# Altium schematic sheet BG0249_R1M1E1_BW235_IMX378.SchDoc(BG0249_R1M1E1_BW235_IMX378)
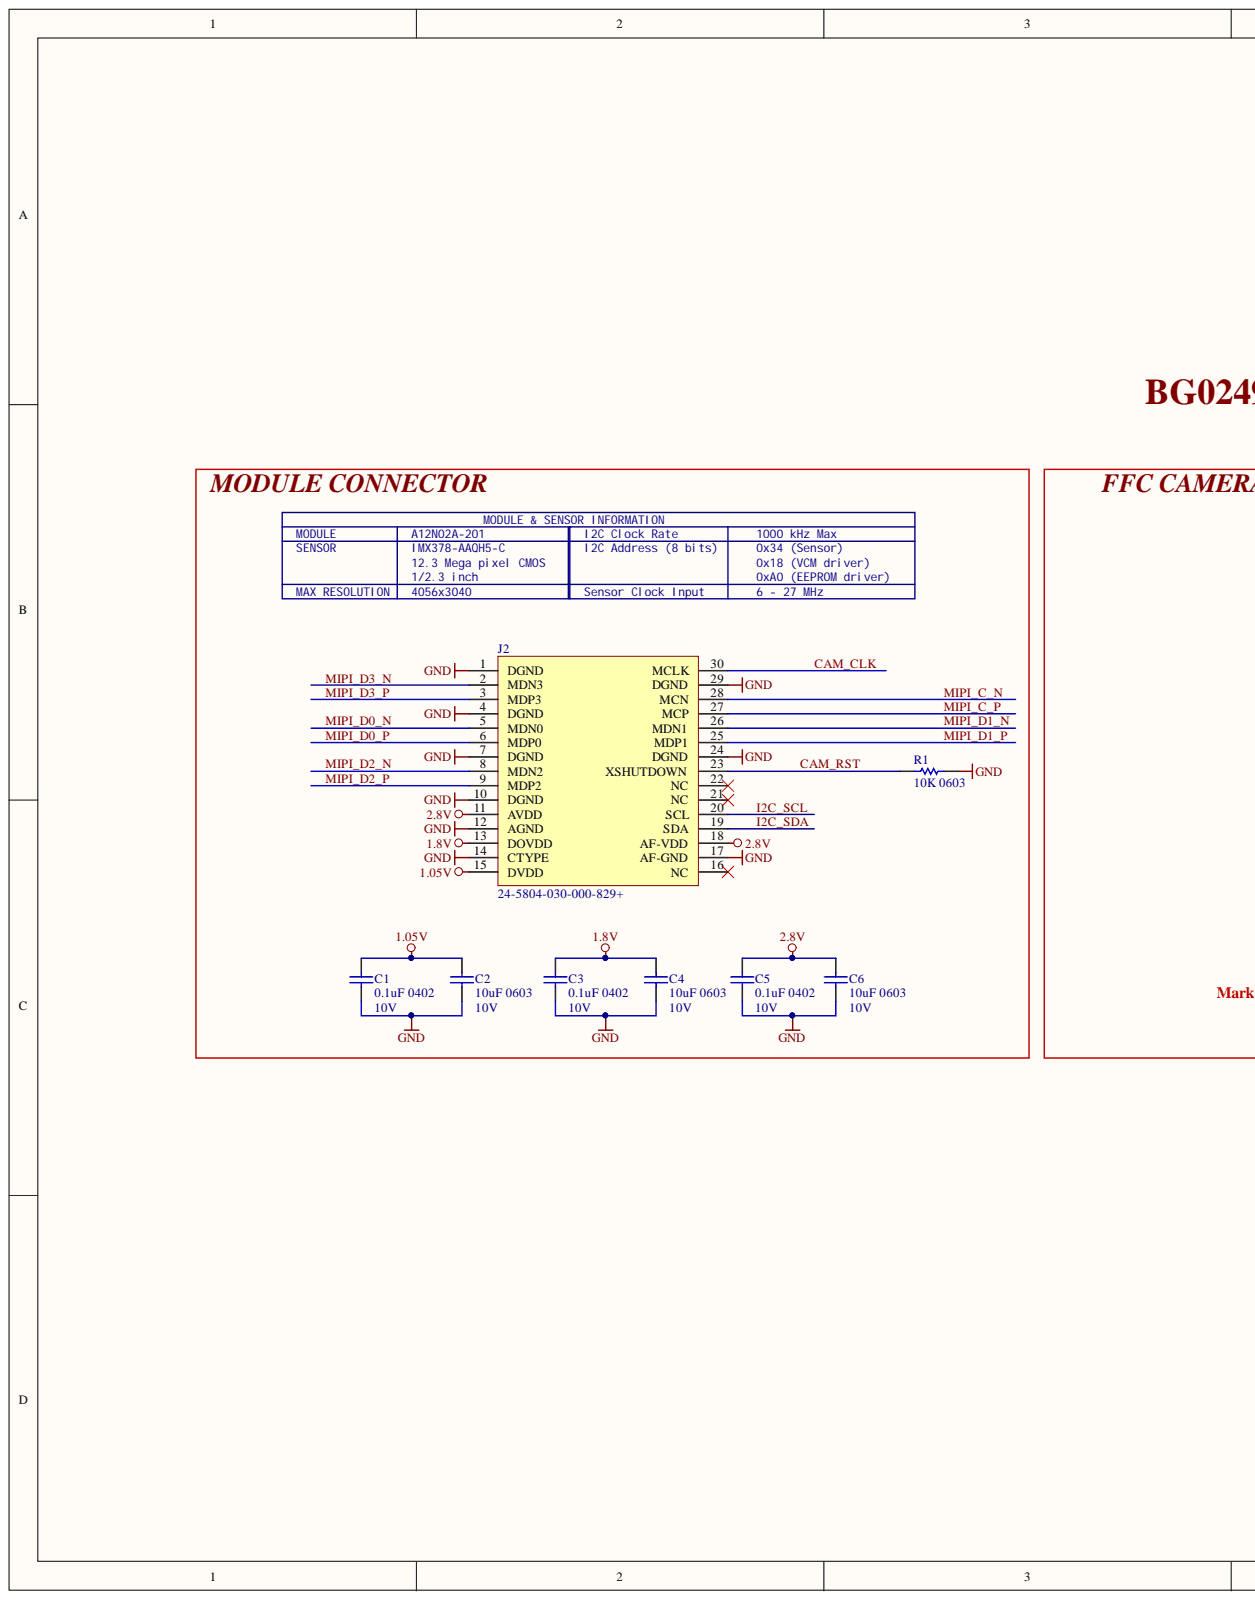
[diagram p0: BG0249_R1M1E1_BW235_IMX378.SchDoc, left]
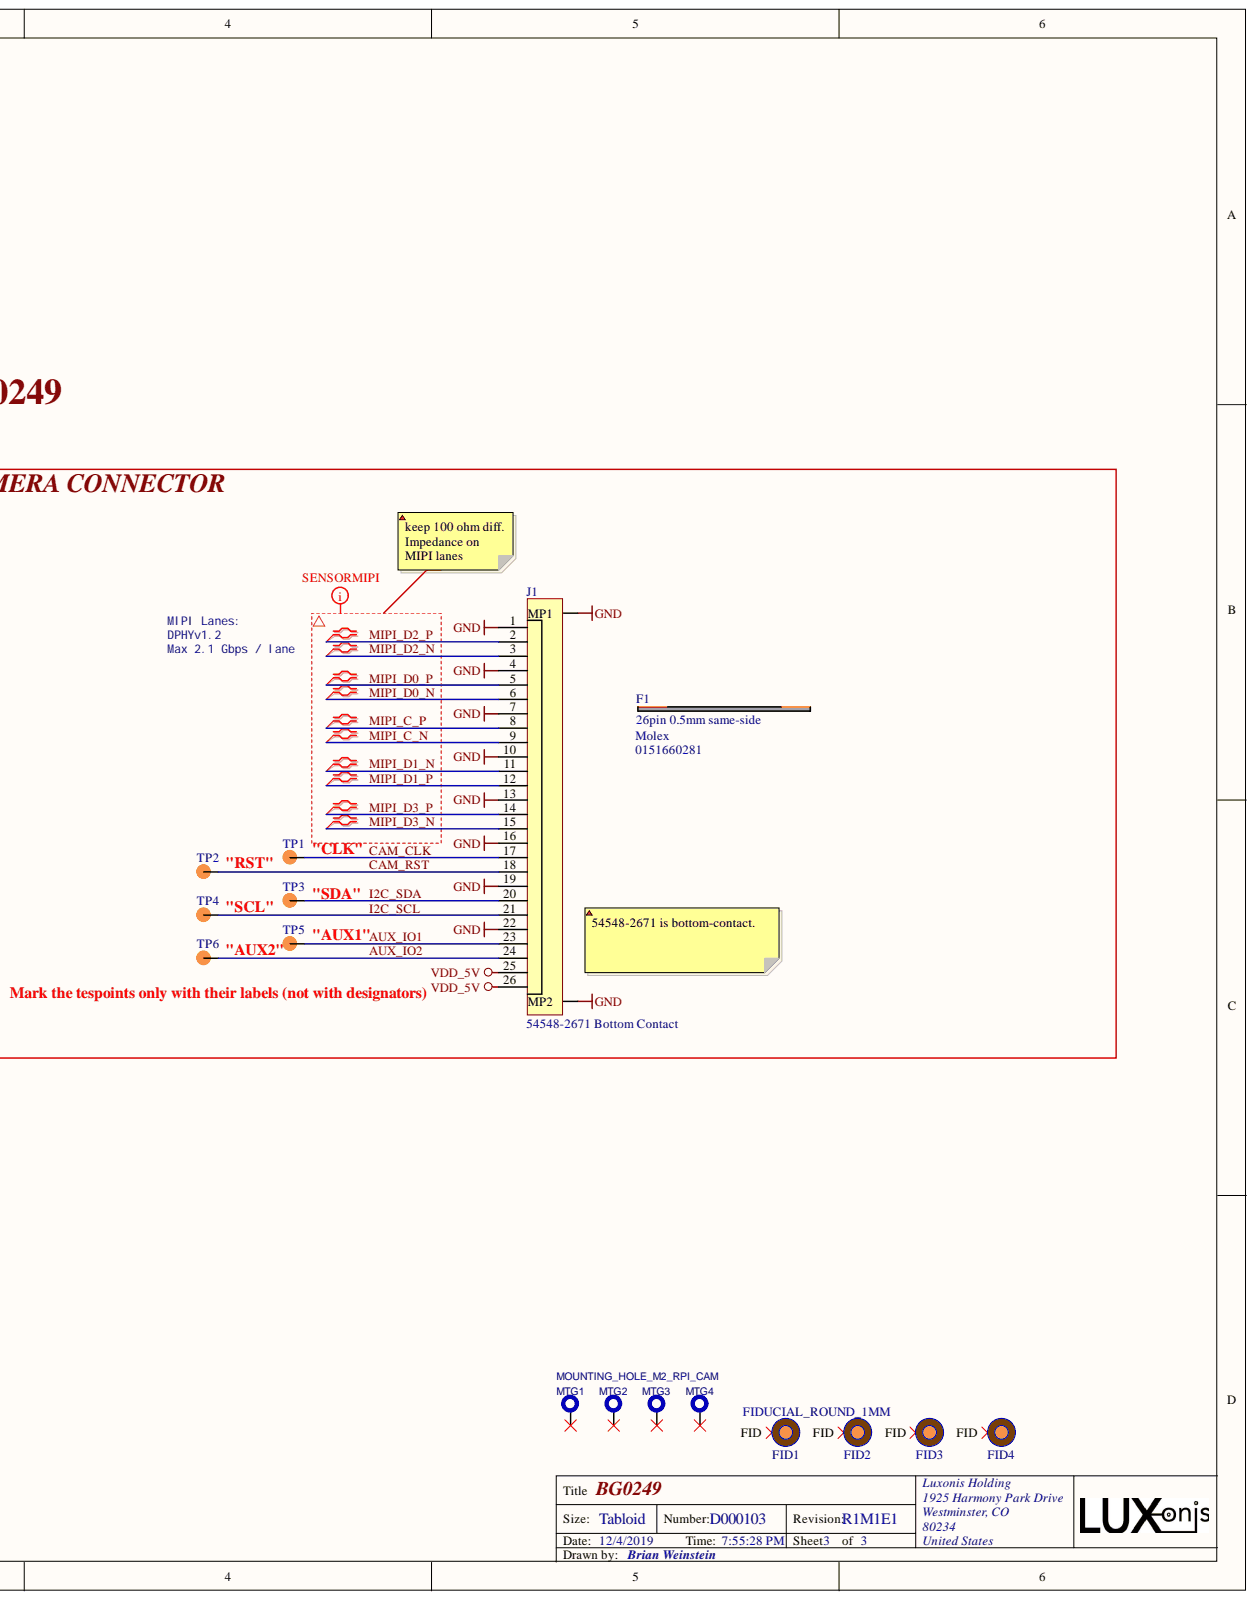
[diagram p1: BG0249_R1M1E1_BW235_IMX378.SchDoc, right]

## Parts
C1  0.1uF 0402  Voltage Rating (DC): 10V  [CAPC0402(1005)70_N]
C2  10uF 0603  Voltage Rating (DC): 10V  Capacitance: 10uF  [CAPC0603(1608)100_N]
C3  0.1uF 0402  Voltage Rating (DC): 10V  [CAPC0402(1005)70_N]
C4  10uF 0603  Voltage Rating (DC): 10V  Capacitance: 10uF  [CAPC0603(1608)100_N]
C5  0.1uF 0402  Voltage Rating (DC): 10V  [CAPC0402(1005)70_N]
C6  10uF 0603  Voltage Rating (DC): 10V  Case Code (Imperial): 0603  Capacitance: 10uF  [CAPC0603(1608)100_N]
F1  26pin 0.5mm same-side  Manufacturer 1: Molex  Cable Pitch: 0.5mm  (mechanical)
FID1  FIDUCIAL_ROUND_1MM  [FIDUCIAL_ROUND_1MM]  (no BOM)
FID2  FIDUCIAL_ROUND_1MM  [FIDUCIAL_ROUND_1MM]  (no BOM)
FID3  FIDUCIAL_ROUND_1MM  [FIDUCIAL_ROUND_1MM]  (no BOM)
FID4  FIDUCIAL_ROUND_1MM  [FIDUCIAL_ROUND_1MM]  (no BOM)
J1  54548-2671 Bottom Contact  [54548-2671]
J2  24-5804-030-000-829+  [A12N02A-201_MatedModule]
MTG1  MOUNTING_HOLE_M2_RPI_CAM  [MOUNTING_HOLE_M2_RPI_CAM]  (no BOM)
MTG2  MOUNTING_HOLE_M2_RPI_CAM  [MOUNTING_HOLE_M2_RPI_CAM]  (no BOM)
MTG3  MOUNTING_HOLE_M2_RPI_CAM  [MOUNTING_HOLE_M2_RPI_CAM]  (no BOM)
MTG4  MOUNTING_HOLE_M2_RPI_CAM  [MOUNTING_HOLE_M2_RPI_CAM]  (no BOM)
R1  10K 0603  Case Code (Imperial): 0603  [RESC0603(1608)_N]
TP1  TP100_150_NS  [TP100_150_NS]  (value not drawn)  (no BOM)
TP2  TP100_150_NS  [TP100_150_NS]  (value not drawn)  (no BOM)
TP3  TP100_150_NS  [TP100_150_NS]  (value not drawn)  (no BOM)
TP4  TP100_150_NS  [TP100_150_NS]  (value not drawn)  (no BOM)
TP5  TP100_150_NS  [TP100_150_NS]  (value not drawn)  (no BOM)
TP6  TP100_150_NS  [TP100_150_NS]  (value not drawn)  (no BOM)

## Nets
1.05V: C1.2 C2.2 J2.15(DVDD)
1.8V: C3.2 C4.2 J2.13(DOVDD)
2.8V: C5.2 C6.2 J2.11(AVDD) J2.18(AF-VDD)
GND: C1.1 C2.1 C3.1 C4.1 C5.1 C6.1 J1.1 J1.4 J1.7 J1.10 J1.13 J1.16 J1.19 J1.22 J1.MP1 J1.MP2 J2.1(DGND) J2.4(DGND) J2.7(DGND) J2.10(DGND) J2.12(AGND) J2.14(CTYPE) J2.17(AF-GND) J2.24(DGND) J2.29(DGND) R1.2
VDD_5V: J1.25 J1.26
AUX_IO1: J1.23 TP5.1
AUX_IO2: J1.24 TP6.1
CAM_CLK: J1.17 J2.30(MCLK) TP1.1
CAM_RST: J1.18 J2.23(XSHUTDOWN) R1.1 TP2.1
I2C_SCL: J1.21 J2.20(SCL) TP4.1
I2C_SDA: J1.20 J2.19(SDA) TP3.1
MIPI_C_N: J1.9 J2.28(MCN)
MIPI_C_P: J1.8 J2.27(MCP)
MIPI_D0_N: J1.6 J2.5(MDN0)
MIPI_D0_P: J1.5 J2.6(MDP0)
MIPI_D1_N: J1.11 J2.26(MDN1)
MIPI_D1_P: J1.12 J2.25(MDP1)
MIPI_D2_N: J1.3 J2.8(MDN2)
MIPI_D2_P: J1.2 J2.9(MDP2)
MIPI_D3_N: J1.15 J2.2(MDN3)
MIPI_D3_P: J1.14 J2.3(MDP3)
N00021:

Not connected: FID1 FID; FID2 FID; FID3 FID; FID4 FID; J2 16(NC) 21(NC) 22(NC); MTG1 1; MTG2 1; MTG3 1; MTG4 1

## Library data of the parts
C1 C3 C5 = GRM155R71A104JA01D: CAP CER 0.1UF 10V X7R 0402 5%; Manufacturer 1: Murata; Capacitance: 100nF; Width: 0.02inch; Voltage Rating: 10V; Tolerance: 5%; Thickness: 0.022inch; Termination: SMD/SMT; Supplier Part Number 1: 490-10777-1-ND; Supplier 1: Digi-Key; Stock 1: 1346709; RoHS: Yes; Radiation Hardening: No; Pricing 1: 1=0.10000, 10=0.02500, 100=0.01130, 500=0.00806, 1000=0.00633, 2500=0.00575, 5000=0.00529 (USD); Packaging: Tape and Reel; Package Quantity: 10000; Mount: Surface Mount; Min Operating Temperature: -55°C; Max Operating Temperature: 125°C; Manufacturer Part Number 1: GRM155R71A104JA01D; Length: 1mm; Lead Free: Lead Free; Height: 0.5mm; Dielectric: X7R; Depth: 0.5mm; ComponentLink2URL: https://datasheet.ciiva.com/11618/c02e-11618821.pdf?src-supplier=Avnet; ComponentLink2Description: https://datasheet.ciiva.com/11618/c02e-11618821.pdf?src-supplier=Avnet; ComponentLink1URL: https://datasheet.ciiva.com/5411/product-5411135.pdf?src-supplier=Mouser; ComponentLink1Description: https://datasheet.ciiva.com/5411/product-5411135.pdf?src-supplier=Mouser; Component Kind: Standard; Case Code (Metric): 1005; Case Code (Imperial): 0402; Case/Package: 0402; Library Name: LuxonisMaster.SchLib
C2 C4 C6 = GRM188R61A106ME69D: CAP CER 10UF 10V X5R 0603 20%; Manufacturer 1: Murata; Material: Ceramic; Width: 0.8mm; Weight: 0.000222oz; Voltage Rating: 10V; Tolerance: 20%; Thickness: 0.035inch; Termination: SMD/SMT; Supplier Part Number 1: 490-10475-1-ND; Supplier 1: Digi-Key; Stock 1: 2873098; RoHS: Yes; REACH SVHC: Unknown; Pricing 1: 1=0.21000, 10=0.14100, 100=0.07130, 500=0.04876, 1000=0.04313 (USD); Packaging: Tape and Reel; Package Quantity: 4000; Mount: Surface Mount; Min Operating Temperature: -55°C; Max Operating Temperature: 85°C; Manufacturer Part Number 1: GRM188R61A106ME69D; Length: 1.6mm; Lead Free: Lead Free; Height: 0.8mm; Dielectric: X5R; Depth: 0.8mm; ComponentLink8URL: http://www.farnell.com/datasheets/2048014.pdf?src-supplier=Newark; ComponentLink8Description: http://www.farnell.com/datasheets/2048014.pdf?src-supplier=Newark; ComponentLink7URL: http://www.farnell.com/datasheets/2048014.pdf?src-supplier=Element14; ComponentLink7Description: http://www.farnell.com/datasheets/2048014.pdf?src-supplier=Element14; ComponentLink6URL: http://www.farnell.com/datasheets/1872210.pdf?src-supplier=Newark; ComponentLink6Description: http://www.farnell.com/datasheets/1872210.pdf?src-supplier=Newark; ComponentLink5URL: http://search.murata.co.jp/Ceramy/image/img/A01X/G101/ENG/GRM188R61A106ME69-01.pdf?src-supplier=Digi-Key; ComponentLink5Description: http://search.murata.co.jp/Ceramy/image/img/A01X/G101/ENG/GRM188R61A106ME69-01.pdf?src-supplier=Digi-Key; ComponentLink4URL: http://www.farnell.com/datasheets/1872210.pdf?src-supplier=Farnell; ComponentLink4Description: http://www.farnell.com/datasheets/1872210.pdf?src-supplier=Farnell; ComponentLink3URL: http://www.farnell.com/datasheets/2048014.pdf?src-supplier=Farnell; ComponentLink3Description: http://www.farnell.com/datasheets/2048014.pdf?src-supplier=Farnell; ComponentLink2URL: http://components.arrow.com/part/dataview/0323_06119472?src-supplier=Arrow+Europe; ComponentLink2Description: http://components.arrow.com/part/dataview/0323_06119472?src-supplier=Arrow+Europe; ComponentLink1URL: http://www.mouser.com/ds/2/281/c02e-2905.pdf?src-supplier=Mouser; ComponentLink1Description: http://www.mouser.com/ds/2/281/c02e-2905.pdf?src-supplier=Mouser; Component Kind: Standard; Case Code (Metric): 1608; Case/Package: 0603; Library Name: LuxonisMaster.SchLib
  C2 C4: Case Code (Imperial): 0603
F1 = 0151660281: CABLE FFC 26POS 0.50MM 6"; Wire/Cable Type: Flat Flex; Pitch: 0.02inch; Number of Conductors: 26; Supplier Part Number 2: 538-15166-0281; Supplier Part Number 1: WM11334-ND; Supplier 2: Mouser; Supplier 1: Digi-Key; Stock 1: 118; RoHS: Yes; Radiation Hardening: No; Pricing 1: 1=2.21000, 10=2.01000, 25=1.88680, 50=1.80460, 100=1.72260, 250=1.55856, 500=1.43552, 1000=1.23045, 2500=1.14842 (USD); Packaging: Bulk; Package Quantity: 1000; Number of Pins: 26; Manufacturer Part Number 1: 0151660281; Library Name: BG0249_R1M1E1.SchLib; Length: 6inch; ComponentLink2URL: https://datasheet.ciiva.com/2944/151660051-sd-2944673.pdf?src-supplier=Digi-Key; ComponentLink2Description: https://datasheet.ciiva.com/2944/151660051-sd-2944673.pdf?src-supplier=Digi-Key; ComponentLink1URL: https://datasheet.ciiva.com/10989/0151660281-cable-10989152.pdf?src-supplier=Avnet; ComponentLink1Description: https://datasheet.ciiva.com/10989/0151660281-cable-10989152.pdf?src-supplier=Avnet
FID1-FID4 = FIDUCIAL: Fiducial with various available footprints; Library Name: LuxonisMaster.SchLib
J1 = 54548-2671: CONN FFC BOTTOM 26POS 0.50MM R/A 26 Position FFC, FPC Connector Contacts, Bottom 0.020" (0.50mm) Surface Mount, Right Angle; Manufacturer_Name: Molex; Wire/Cable Type: Straight; Voltage Rating: 50V; Pitch: 0.5mm; Number of Positions: 26; Current Rating: 500mA; Contact Resistance: 40mR; Actuator Material: Polyphenylene; Termination: Solder; Supplier Part Number 2: 0545482671; Supplier Part Number 1: 538-54548-2671; Supplier 2: Arrow; Supplier 1: Mouser; Stock 2: 11173; Stock 1: 6344; RoHS: Yes; Pricing 2: 1=3.50200, 10=3.34800, 25=3.06600, 100=2.78390, 250=2.43800, 500=2.41400, 1000=2.25990, 3000=2.10600, 6000=1.95200, 12000=1.73300, 24000=1.71600, 48000=1.69900, 60000=1.68200 (USD); Pricing 1: 1=3.03000, 10=2.86000, 25=2.55000, 100=2.42000, 250=2.12000, 500=2.07000 (USD); Packaging: Tape and Reel; Package Quantity: 750; Orientation: Right Angle; Number of Rows: 1; Number of Contacts: 26; Mouser Price/Stock: https://eu.mouser.com/ProductDetail/Molex/54548-2671?qs=sGAEpiMZZMs7i6cT6ogu49DbkzKdWzYdwOJCTNigfgU%3D; Mouser Part Number: 538-54548-2671; Mount: Surface Mount; Min Operating Temperature: -20°C; Max Operating Temperature: 85°C; Manufacturer Part Number 2: 54548-2671; Manufacturer Part Number 1: 54548-2671; Manufacturer_Part_Number: 54548-2671; Manufacturer 2: Molex; Manufacturer 1: Molex; Length: 18.2mm; Housing Material: Polymer; Housing Color: Black; Height: 1mm; Flammability Rating: UL94 V-0; Depth: 5mm; Datasheet Link: https://www.molex.com/molex/products/datasheet.jsp?part=active/0545482671_FFC_FPC_CONNECTORS.xml&channel=Products&Lang=en-US; Contact Plating: Gold; Contact Material: Bronze; ComponentLink1URL: https://datasheet.ciiva.com/5137/0545482671-ffc-fpc-connectors-146697-5137857.pdf?src-supplier=Mouser; ComponentLink1Description: https://datasheet.ciiva.com/5137/0545482671-ffc-fpc-connectors-146697-5137857.pdf?src-supplier=Mouser; Component Kind: Standard; Library Name: LuxonisMaster.SchLib
J2 = A12N02A-201_Connector: CONN Series 5804 board to board 0.4mm pitch 30 way connector; Manufacturer Part Number 1: 245804030000829+; Manufacturer 1: Kyocera International Electronic Components; MAN: AVX/KYOCERA; Supplier Part Number 1: 478-12013-1-ND; Supplier 1: Digi-Key; Stock 1: 11469; RoHS: No; Pricing 1: 1=1.69000, 10=1.53600, 25=1.44200, 50=1.37940, 100=1.31670, 250=1.19132, 500=1.09726, 1000=0.94050 (USD); MAN PN: 24-5804-030-000-829+; ComponentLink1URL: http://global.kyocera.com/prdct/electro/product/pdf/5804.pdf?src-supplier=Digi-Key; ComponentLink1Description: http://global.kyocera.com/prdct/electro/product/pdf/5804.pdf?src-supplier=Digi-Key; Component Kind: Standard; Library Name: LuxonisMaster.SchLib
MTG1-MTG4 = (no symbol name): =CurrentFootprint; B - Value: Not a Part; A - Level: 0; Description: MOUNTING_HOLE_M2_RPI_CAM; Library Name: LuxonisMaster.SchLib
R1 = RC0603FR-0710KL: RES SMD 10K OHM 1% 1/10W 0603; Manufacturer 1: Yageo; Voltage Rating (DC): 50V; Voltage Rating: 75V; Temperature Coefficient: 100ppm/°C; Resistance: 10kR; Power Rating: 100mW; Width: 0.8mm; Weight: 7.1E-05oz; Tolerance: 1%; Termination: SMD/SMT; Supplier Part Number 3: 311-10.0KHRTR-ND; Supplier Part Number 2: 311-10.0KHRDKR-ND; Supplier Part Number 1: 311-10.0KHRCT-ND; Supplier 3: Digi-Key; Supplier 2: Digi-Key; Supplier 1: Digi-Key; Stock 3: 80410000; Stock 2: 80414305; Stock 1: 80414305; RoHS: Yes; REACH SVHC: No SVHC; Pricing 3: 5000=0.00261, 10000=0.00227, 25000=0.00199, 50000=0.00183, 125000=0.00179 (USD); Pricing 2: 1=0.10000, 10=0.02200, 100=0.00890, 1000=0.00401, 2500=0.00348 (USD); Pricing 1: 1=0.10000, 10=0.02200, 100=0.00890, 1000=0.00401, 2500=0.00348 (USD); Packaging: Tape and Reel; Number of Pins: 2; Min Operating Temperature: -55°C; Military Standard: Not; Max Operating Temperature: 155°C; Manufacturer Part Number 3: RC0603FR-0710KL; Manufacturer Part Number 2: RC0603FR-0710KL; Manufacturer Part Number 1: RC0603FR-0710KL; Manufacturer 3: Yageo; Manufacturer 2: Yageo; Length: 1.6mm; Lead Pitch: 0.3mm; Lead Free: Lead Free; Height: 0.45mm; Depth: 0.8mm; Composition: Thick Film; ComponentLink6URL: https://datasheet.ciiva.com/22747/443971-22747519.pdf?src-supplier=Element14; ComponentLink6Description: https://datasheet.ciiva.com/22747/443971-22747519.pdf?src-supplier=Element14; ComponentLink5URL: https://datasheet.ciiva.com/7746/1738695-7746239.pdf?src-supplier=Element14; ComponentLink5Description: https://datasheet.ciiva.com/7746/1738695-7746239.pdf?src-supplier=Element14; ComponentLink4URL: https://datasheet.ciiva.com/7983/443971-7983596.pdf?src-supplier=Element14; ComponentLink4Description: https://datasheet.ciiva.com/7983/443971-7983596.pdf?src-supplier=Element14; ComponentLink3URL: https://datasheet.ciiva.com/46440/1868787-46440891.pdf?src-supplier=Element14; ComponentLink3Description: https://datasheet.ciiva.com/46440/1868787-46440891.pdf?src-supplier=Element14; ComponentLink2URL: https://datasheet.ciiva.com/15189/getdatasheetpartid-409289-15189591.pdf?src-supplier=Verical; ComponentLink2Description: https://datasheet.ciiva.com/15189/getdatasheetpartid-409289-15189591.pdf?src-supplier=Verical; ComponentLink1URL: https://datasheet.ciiva.com/7660/38590-7660789.pdf?src-supplier=Element14; ComponentLink1Description: https://datasheet.ciiva.com/7660/38590-7660789.pdf?src-supplier=Element14; Component Kind: Standard; Case Code (Metric): 1608; Case/Package: 0603; Library Name: LuxonisMaster.SchLib
TP1-TP6 = TEST_POINT: Library Name: LuxonisMaster.SchLib
